# Altium schematic sheet BREEZE Pro ESC-C V1.SchDoc
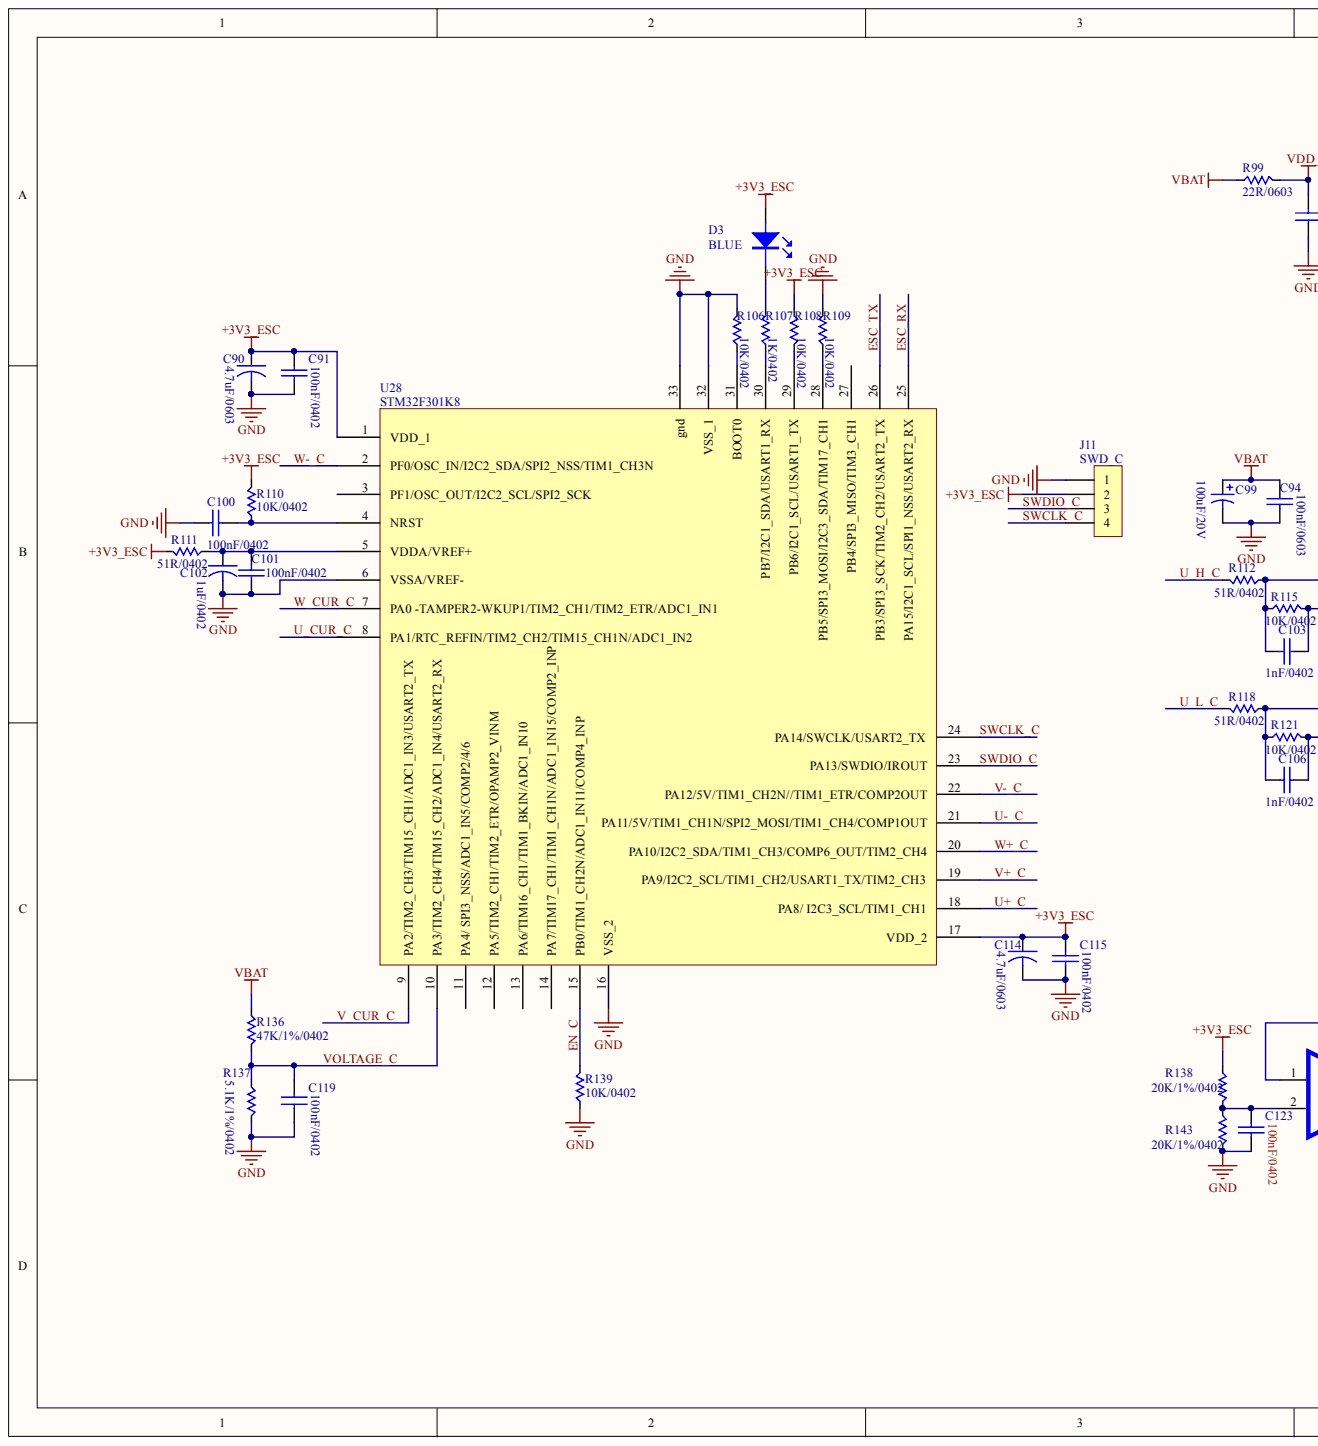
[diagram p0: BREEZE Pro ESC-C V1.SchDoc, left]
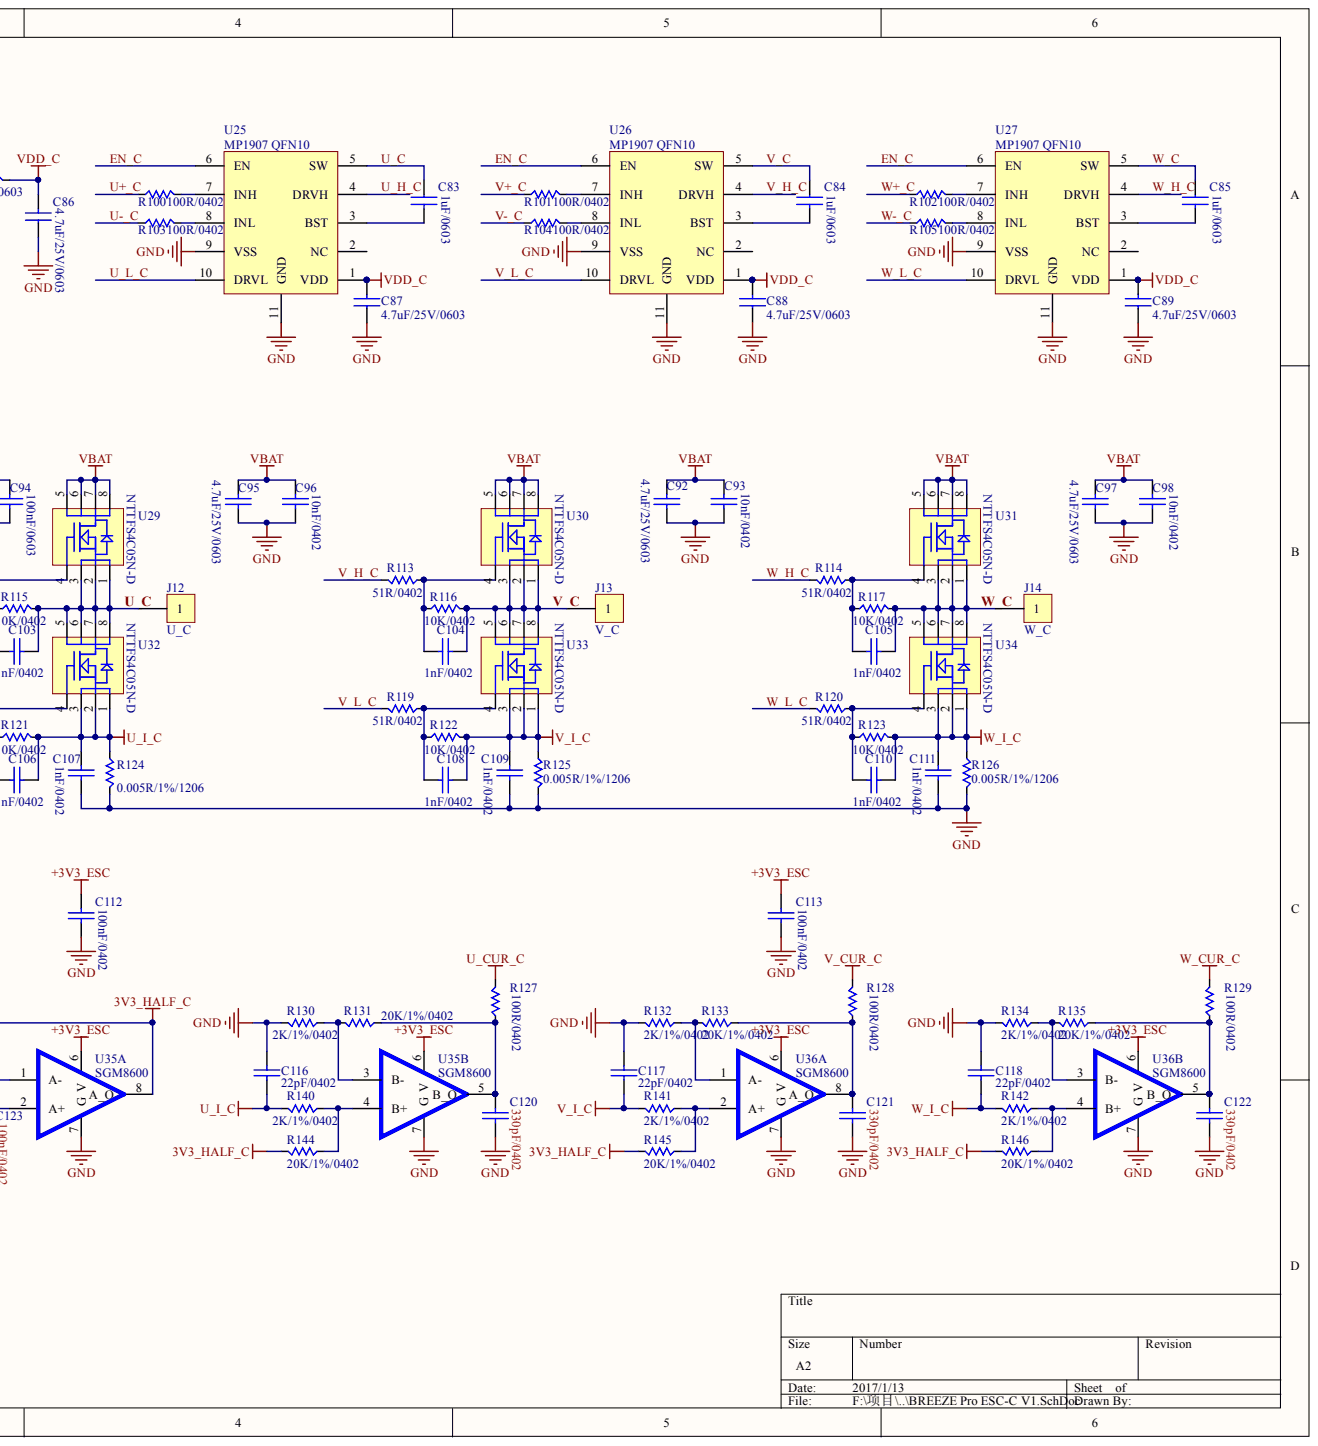
[diagram p1: BREEZE Pro ESC-C V1.SchDoc, right]

## Parts
C83  1uF/0603
C84  1uF/0603
C85  1uF/0603
C86  4.7uF/25V/0603
C87  4.7uF/25V/0603
C88  4.7uF/25V/0603
C89  4.7uF/25V/0603
C90  4.7uF/0603
C91  100nF/0402
C92  4.7uF/25V/0603
C93  10nF/0402
C94  100nF/0603
C95  4.7uF/25V/0603
C96  10nF/0402
C97  4.7uF/25V/0603
C98  10nF/0402
C99  100uF/20V
C100  100nF/0402
C101  100nF/0402
C102  1uF/0402
C103  1nF/0402
C104  1nF/0402
C105  1nF/0402
C106  1nF/0402
C107  1nF/0402
C108  1nF/0402
C109  1nF/0402
C110  1nF/0402
C111  1nF/0402
C112  100nF/0402
C113  100nF/0402
C114  4.7uF/0603
C115  100nF/0402
C116  22pF/0402
C117  22pF/0402
C118  22pF/0402
C119  100nF/0402
C120  330pF/0402
C121  330pF/0402
C122  330pF/0402
C123  100nF/0402
D3  BLUE
J11  SWD_C
J12  U_C
J13  V_C
J14  W_C
R99  22R/0603
R100  100R/0402
R101  100R/0402
R102  100R/0402
R103  100R/0402
R104  100R/0402
R105  100R/0402
R106  10K/0402
R107  1K/0402
R108  10K/0402
R109  10K/0402
R110  10K/0402
R111  51R/0402
R112  51R/0402
R113  51R/0402
R114  51R/0402
R115  10K/0402
R116  10K/0402
R117  10K/0402
R118  51R/0402
R119  51R/0402
R120  51R/0402
R121  10K/0402
R122  10K/0402
R123  10K/0402
R124  0.005R/1%/1206
R125  0.005R/1%/1206
R126  0.005R/1%/1206
R127  100R/0402
R128  100R/0402
R129  100R/0402
R130  2K/1%/0402
R131  20K/1%/0402
R132  2K/1%/0402
R133  20K/1%/0402
R134  2K/1%/0402
R135  20K/1%/0402
R136  47K/1%/0402
R137  5.1K/1%/0402
R138  20K/1%/0402
R139  10K/0402
R140  2K/1%/0402
R141  2K/1%/0402
R142  2K/1%/0402
R143  20K/1%/0402
R144  20K/1%/0402
R145  20K/1%/0402
R146  20K/1%/0402
U25  MP1907 QFN10
U26  MP1907 QFN10
U27  MP1907 QFN10
U28  STM32F301K8
U29  NTTFS4C05N-D
U30  NTTFS4C05N-D
U31  NTTFS4C05N-D
U32  NTTFS4C05N-D
U33  NTTFS4C05N-D
U34  NTTFS4C05N-D
U35  SGM8600  (parts A,B)
U36  SGM8600  (parts A,B)

## Nets
3V3_HALF_C: R144.2 R145.2 R146.2 U35.1(A-) U35.8(A_O)
+3V3_ESC: C90.1 C91.1 C112.1 C113.1 C114.1 C115.1 D3.1 J11.2 R108.1 R110.2 R111.2 R138.1 U28.1(VDD_1) U28.17(VDD_2) U35.6(V) U36.6(V)
GND: C86.1 C87.1 C88.1 C89.1 C90.2 C91.2 C92.2 C93.2 C94.2 C95.2 C96.2 C97.2 C98.2 C99.2 C100.2 C101.2 C102.2 C107.2 C109.2 C111.2 C112.2 C113.2 C114.2 C115.2 C116.1 C117.1 C118.1 C119.2 C120.1 C121.1 C122.1 C123.1 J11.1 R106.2 R109.2 R124.2 R125.2 R126.2 R130.2 R132.2 R134.2 R137.1 R139.1 R143.2 U25.9(VSS) U25.11(GND) U26.9(VSS) U26.11(GND) U27.9(VSS) U27.11(GND) U28.6(VSSA/VREF-) U28.16(VSS_2) U28.32(VSS_1) U28.33(gnd) U35.7(G) U36.7(G)
U_I_C: C106.2 C107.1 C116.2 R121.2 R124.1 R140.2 U32.1 U32.2 U32.3
V_I_C: C108.2 C109.1 C117.2 R122.2 R125.1 R141.2 U33.1 U33.2 U33.3
VBAT: C92.1 C93.1 C94.1 C95.1 C96.1 C97.1 C98.1 C99.1 R99.1 R136.2 U29.5 U29.6 U29.7 U29.8 U30.5 U30.6 U30.7 U30.8 U31.5 U31.6 U31.7 U31.8
VDD_C: C86.2 C87.2 C88.2 C89.2 R99.2 U25.1(VDD) U26.1(VDD) U27.1(VDD)
W_I_C: C110.2 C111.1 C118.2 R123.2 R126.1 R142.2 U34.1 U34.2 U34.3
EN_C: R139.2 U25.6(EN) U26.6(EN) U27.6(EN) U28.15(PB0/TIM1_CH2N/ADC1_IN11/COMP4_INP)
ESC_RX: U28.25(PA15/I2C1_SCL/SPI1_NSS/USART2_RX)
ESC_TX: U28.26(PB3/SPI3_SCK/TIM2_CH2/USART2_TX)
SWCLK_C: J11.4 U28.24(PA14/SWCLK/USART2_TX)
SWDIO_C: J11.3 U28.23(PA13/SWDIO/IROUT)
U+_C: R100.1 U28.18(PA8/_I2C3_SCL/TIM1_CH1)
U-_C: R103.1 U28.21(PA11/5V/TIM1_CH1N/SPI2_MOSI/TIM1_CH4/COMP1OUT)
U_C: C83.1 C103.2 J12.1 R115.2 U25.5(SW) U29.1 U29.2 U29.3 U32.5 U32.6 U32.7 U32.8
U_CUR_C: R127.2 U28.8(PA1/RTC_REFIN/TIM2_CH2/TIM15_CH1N/ADC1_IN2)
U_H_C: R112.2 U25.4(DRVH)
U_L_C: R118.2 U25.10(DRVL)
V+_C: R101.1 U28.19(PA9/I2C2_SCL/TIM1_CH2/USART1_TX/TIM2_CH3)
V-_C: R104.1 U28.22(PA12/5V/TIM1_CH2N//TIM1_ETR/COMP2OUT)
V_C: C84.1 C104.2 J13.1 R116.2 U26.5(SW) U30.1 U30.2 U30.3 U33.5 U33.6 U33.7 U33.8
V_CUR_C: R128.2 U28.9(PA2/TIM2_CH3/TIM15_CH1/ADC1_IN3/USART2_TX)
V_H_C: R113.2 U26.4(DRVH)
V_L_C: R119.2 U26.10(DRVL)
VOLTAGE_C: C119.1 R136.1 R137.2 U28.10(PA3/TIM2_CH4/TIM15_CH2/ADC1_IN4/USART2_RX)
W+_C: R102.1 U28.20(PA10/I2C2_SDA/TIM1_CH3/COMP6_OUT/TIM2_CH4)
W-_C: R105.1 U28.2(PF0/OSC_IN/I2C2_SDA/SPI2_NSS/TIM1_CH3N)
W_C: C85.1 C105.2 J14.1 R117.2 U27.5(SW) U31.1 U31.2 U31.3 U34.5 U34.6 U34.7 U34.8
W_CUR_C: R129.2 U28.7(PA0_-TAMPER2-WKUP1/TIM2_CH1/TIM2_ETR/ADC1_IN1)
W_H_C: R114.2 U27.4(DRVH)
W_L_C: R120.2 U27.10(DRVL)
NetC83_2: C83.2 U25.3(BST)
NetC84_2: C84.2 U26.3(BST)
NetC85_2: C85.2 U27.3(BST)
NetC100_1: C100.1 R110.1 U28.4(NRST)
NetC101_1: C101.1 C102.1 R111.1 U28.5(VDDA/VREF+)
NetC103_1: C103.1 R112.1 R115.1 U29.4
NetC104_1: C104.1 R113.1 R116.1 U30.4
NetC105_1: C105.1 R114.1 R117.1 U31.4
NetC106_1: C106.1 R118.1 R121.1 U32.4
NetC108_1: C108.1 R119.1 R122.1 U33.4
NetC110_1: C110.1 R120.1 R123.1 U34.4
NetC120_2: C120.2 R127.1 R131.1 U35.5(B_O)
NetC121_2: C121.2 R128.1 R133.1 U36.8(A_O)
NetC122_2: C122.2 R129.1 R135.1 U36.5(B_O)
NetC123_2: C123.2 R138.2 R143.1 U35.2(A+)
NetD3_2: D3.2 R107.1
NetR100_2: R100.2 U25.7(INH)
NetR101_2: R101.2 U26.7(INH)
NetR102_2: R102.2 U27.7(INH)
NetR103_2: R103.2 U25.8(INL)
NetR104_2: R104.2 U26.8(INL)
NetR105_2: R105.2 U27.8(INL)
NetR106_1: R106.1 U28.31(BOOT0)
NetR107_2: R107.2 U28.30(PB7/I2C1_SDA/USART1_RX)
NetR108_2: R108.2 U28.29(PB6/I2C1_SCL/USART1_TX)
NetR109_1: R109.1 U28.28(PB5/SPI3_MOSI/I2C3_SDA/TIM17_CH1)
NetR130_1: R130.1 R131.2 U35.3(22pF/0402)
NetR132_1: R132.1 R133.2 U36.1(A-)
NetR134_1: R134.1 R135.2 U36.3(22pF/0402)
NetR140_1: R140.1 R144.1 U35.4
NetR141_1: R141.1 R145.1 U36.2(A+)
NetR142_1: R142.1 R146.1 U36.4

Not connected: U25 2(NC); U26 2(NC); U27 2(NC); U28 3(PF1/OSC_OUT/I2C2_SCL/SPI2_SCK) 11(PA4/_SPI3_NSS/ADC1_IN5/COMP2/4/6) 12(PA5/TIM2_CH1/TIM2_ETR/OPAMP2_VINM) 13(PA6/TIM16_CH1/TIM1_BKIN/ADC1_IN10) 14(PA7/TIM17_CH1/TIM1_CH1N/ADC1_IN15/COMP2_INP) 27(PB4/SPI3_MISO/TIM3_CH1)

## Library data of the parts
C83-C89 C119 = (no symbol name): Text Field1: 20; Text Field2: 10
C90-C118 C120-C123 D3 J11-J14 R99-R146 U25-U36 = (no symbol name)
  C99: Published: 8-Jun-2000; LatestRevisionDate: 17-Jul-2002; LatestRevisionNote: Re-released for DXP Platform.; PackageReference: RB7.6-15; Publisher: Altium Limited
